# Altium schematic sheet Peripherals.SchDoc
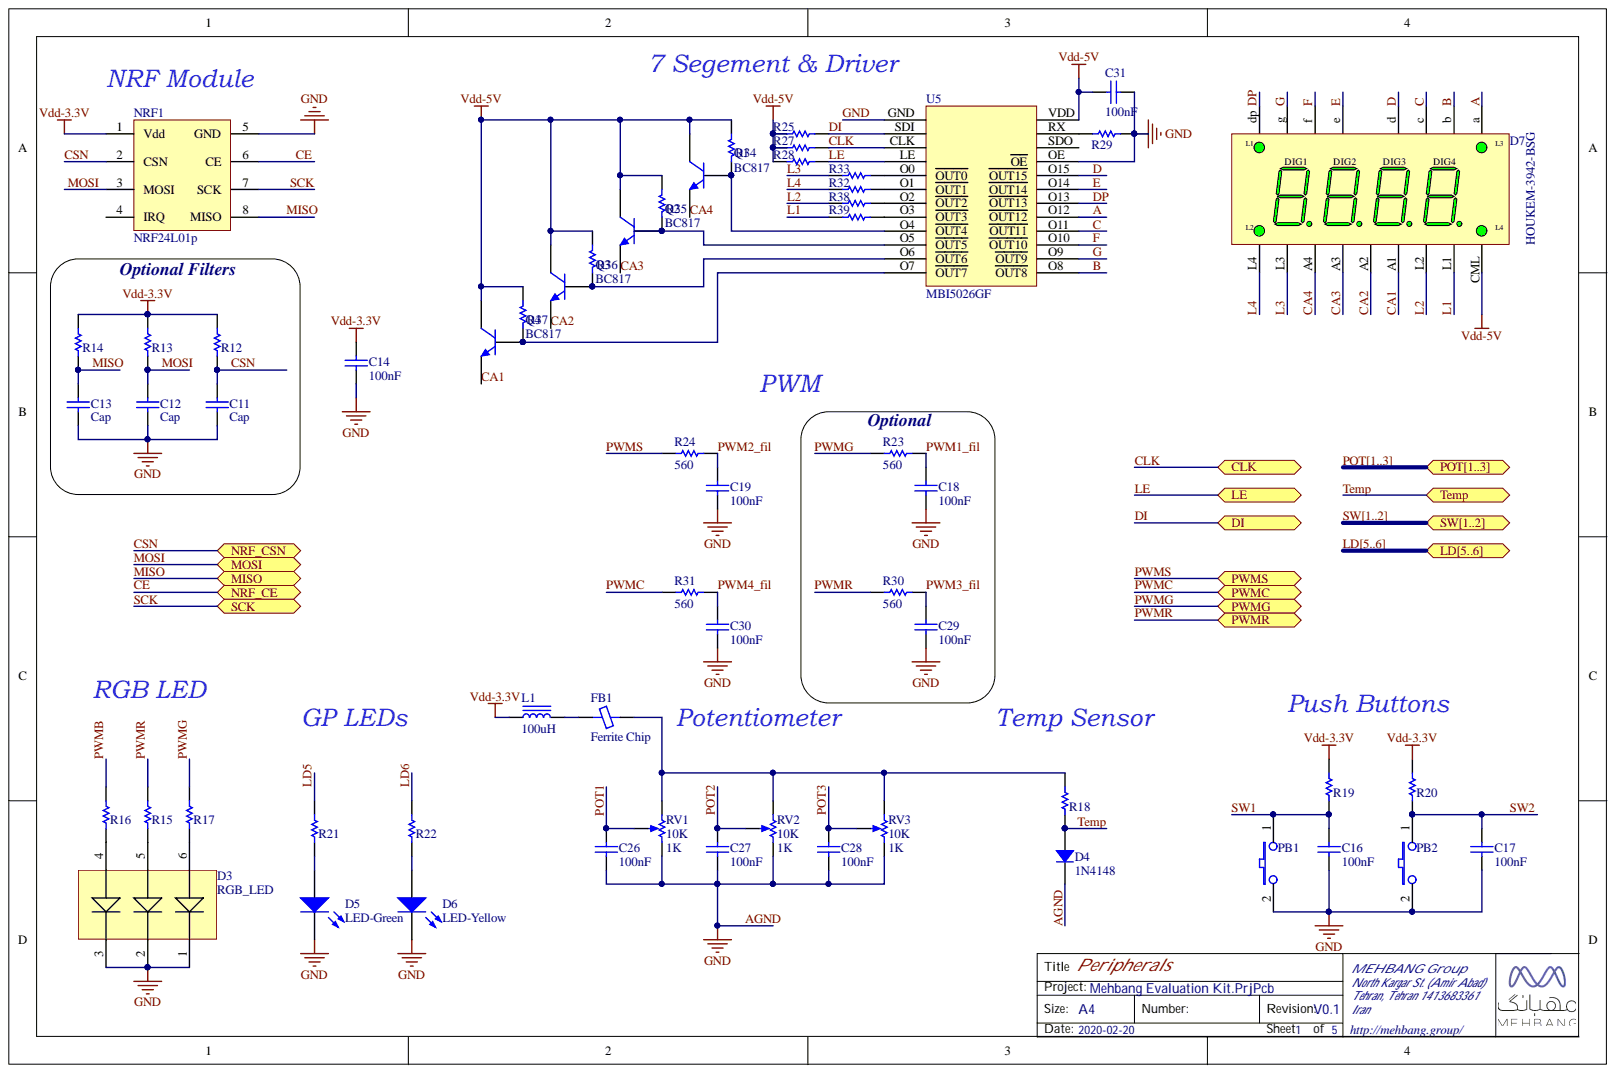
[diagram p0: Peripherals.SchDoc]

## Parts
C11  Cap  [0805-Cap]
C12  Cap  [0805-Cap]
C13  Cap  [0805-Cap]
C14  100nF  [0805-Cap]
C16  100nF  [0805-Cap]
C17  100nF  [0805-Cap]
C18  100nF  [0805-Cap]
C19  100nF  [0805-Cap]
C26  100nF  [0805-Cap]
C27  100nF  [0805-Cap]
C28  100nF  [0805-Cap]
C29  100nF  [0805-Cap]
C30  100nF  [0805-Cap]
C31  100nF  [0805-Cap]
D3  RGB_LED  [RGB 5050 LED]
D4  1N4148  [SOD-123]
D5  LED-Green  [0805-LED]
D6  LED-Yellow  [0805-LED]
D7  HOUKEM-3942-BSG  [7 SEGMENT-4DIGIT]
FB1  Ferrite Chip  [Ferrit Bid]
L1  100uH  [0805-Res]
NRF1  NRF24L01p  [NRF24L01P]
PB1  2 pin tactile switch  [PB-6X6mm-dip-2pin-Black]  (value not drawn)
PB2  2 pin tactile switch  [PB-6X6mm-dip-2pin-Black]  (value not drawn)
Q1  BC817  [SOT-23]
Q2  BC817  [SOT-23]
Q3  BC817  [SOT-23]
Q4  BC817  [SOT-23]
R12  Res  [0805-Res]  (value not drawn)
R13  Res  [0805-Res]  (value not drawn)
R14  Res  [0805-Res]  (value not drawn)
R15  220  [0805-Res]  (value not drawn)
R16  150  [0805-Res]  (value not drawn)
R17  150  [0805-Res]  (value not drawn)
R18  1.2K  [0805-Res]  (value not drawn)
R19  1K  [0805-Res]  (value not drawn)
R20  1K  [0805-Res]  (value not drawn)
R21  220  [0805-Res]  (value not drawn)
R22  330  [0805-Res]  (value not drawn)
R23  560  [0805-Res]
R24  560  [0805-Res]
R25  2.7K  [0805-Res]  (value not drawn)
R27  2.7K  [0805-Res]  (value not drawn)
R28  2.7K  [0805-Res]  (value not drawn)
R29  2.7K  [0805-Res]  (value not drawn)
R30  560  [0805-Res]
R31  560  [0805-Res]
R32  330  [0805-Res]  (value not drawn)
R33  330  [0805-Res]  (value not drawn)
R34  1K  [0805-Res]  (value not drawn)
R35  1K  [0805-Res]  (value not drawn)
R36  1K  [0805-Res]  (value not drawn)
R37  1K  [0805-Res]  (value not drawn)
R38  330  [0805-Res]  (value not drawn)
R39  330  [0805-Res]  (value not drawn)
RV1  10K  value 1K  [POT]
RV2  10K  value 1K  [POT]
RV3  10K  value 1K  [POT]
U5  MBI5026GF  [MBI5026]

## Ports
CLK, DI, LD5, LD6, LD[5..6], LE, MISO, MOSI, NRF_CE, NRF_CSN, POT1, POT2, POT3, POT[1..3], PWMC, PWMG, PWMR, PWMS, SCK, SW1, SW2, SW[1..2], Temp

## Nets
Vdd-3.3V: C14.2 L1.2 NRF1.1(Vdd) R12.2 R13.2 R14.2 R19.2 R20.2
Vdd-5V: C31.2 D7.CML Q1.3 Q2.3 Q3.3 Q4.3 R25.2 R27.2 R28.2 R34.2 R35.2 R36.2 R37.2 U5.VDD
A: D7.a U5.O12(OUT12)
AGND: C11.1 C12.1 C13.1 C14.1 C16.1 C17.1 C18.1 C19.1 C26.1 C27.1 C28.1 C29.1 C30.1 C31.1 D3.1 D3.2 D3.3 D4.2 D5.2 D6.2 NRF1.5(GND) PB1.2 PB2.2 R29.1 RV1.3 RV2.3 RV3.3 U5.GND U5.OE
B: D7.b U5.O8(OUT8)
C: D7.c U5.O11(OUT11)
CA1: D7.A1 Q4.2
CA2: D7.A2 Q3.2
CA3: D7.A3 Q2.2
CA4: D7.A4 Q1.2
CE: NRF1.6(CE) ; port NRF_CE
CLK: R27.1 U5.CLK ; port CLK
CSN: C11.2 NRF1.2(CSN) R12.1 ; port NRF_CSN
D: D7.d U5.O15(OUT15)
DI: R25.1 U5.SDI ; port DI
DP: D7.dp U5.O13(OUT13)
E: D7.e U5.O14(OUT14)
F: D7.f U5.O10(OUT10)
G: D7.g U5.O9(OUT9)
L1: D7.L1 R39.2
L2: D7.L2 R38.2
L3: D7.L3 R33.2
L4: D7.L4 R32.2
LD5: R21.2 ; port LD[5..6]  [also labelled LD]
LD6: R22.2 ; port LD[5..6]  [also labelled LD]
LE: R28.1 U5.LE ; port LE
MISO: C13.2 NRF1.8(MISO) R14.1 ; port MISO
MOSI: C12.2 NRF1.3(MOSI) R13.1 ; port MOSI
POT1: C26.2 RV1.2 ; port POT[1..3]  [also labelled POT]
POT2: C27.2 RV2.2 ; port POT[1..3]  [also labelled POT]
POT3: C28.2 RV3.2 ; port POT[1..3]  [also labelled POT]
PWM1_fil: C18.2 R23.1
PWM2_fil: C19.2 R24.1
PWM3_fil: C29.2 R30.1
PWM4_fil: C30.2 R31.1
PWMB: R16.2
PWMC: R31.2 ; port PWMC
PWMG: R17.2 R23.2 ; port PWMG
PWMR: R15.2 R30.2 ; port PWMR
PWMS: R24.2 ; port PWMS
SCK: NRF1.7(SCK) ; port SCK
SW1: C16.2 PB1.1 R19.1 ; port SW[1..2]  [also labelled SW]
SW2: C17.2 PB2.1 R20.1 ; port SW[1..2]  [also labelled SW]
Temp: D4.1 R18.1 ; port Temp
NetD3_4: D3.4 R16.1
NetD3_5: D3.5 R15.1
NetD3_6: D3.6 R17.1
NetD5_1: D5.1 R21.1
NetD6_1: D6.1 R22.1
NetFB1_1: FB1.1 L1.1
NetFB1_2: FB1.2 R18.2 RV1.1 RV2.1 RV3.1
NetQ1_1: Q1.1 R34.1 U5.O4(OUT4)
NetQ2_1: Q2.1 R35.1 U5.O5(OUT5)
NetQ3_1: Q3.1 R36.1 U5.O6(OUT6)
NetQ4_1: Q4.1 R37.1 U5.O7(OUT7)
NetR29_2: R29.2 U5.RX
NetR32_1: R32.1 U5.O1(OUT1)
NetR33_1: R33.1 U5.O0(OUT0)
NetR38_1: R38.1 U5.O2(OUT2)
NetR39_1: R39.1 U5.O3(OUT3)

Not connected: NRF1 4(IRQ); U5 SDO

## Library data of the parts
C11-C14 C16-C19 C26-C31 = Cap: Capacitor; Component Kind: Standard; LatestRevisionDate: 17-Jul-2002; LatestRevisionNote: Re-released for DXP Platform.; Library Name: DaEvKit-LIB.IntLib; PackageDescription: Radial Cap, Thru-Hole; 2 Leads; 0.3 in Pin Spacing; PackageReference: RAD-0.3; Published: 8-Jun-2000; Publisher: Altium Limited
D3 = LED 5050 RGB: Component Kind: Standard; Library Name: DaEvKit-LIB.IntLib
D4 = Diode: Rectifier Diode; Component Kind: Standard; Library Name: DaEvKit-LIB.IntLib
D5 D6 = LED: Typical RED, GREEN, YELLOW, AMBER GaAs LED; Component Kind: Standard; LatestRevisionDate: 17-Jul-2002; LatestRevisionNote: Re-released for DXP Platform.; Library Name: DaEvKit-LIB.IntLib; PackageDescription: SM LED; 2 Flat Leads; PackageReference: 3.2X1.6X1.1; PackageVersion: Feb-2002; Published: 8-Jun-2000; Publisher: Altium Limited
D7 = 7-Segment: 7-Segment Display: 4-Digit, 4 extra leds; Component Kind: Standard; Library Name: DaEvKit-LIB.IntLib
FB1 = Ferrite Chip: SMT Ferrite Chip; Component Kind: Standard; Library Name: DaEvKit-LIB.IntLib
L1 = Inductor: SMT Inductor; Component Kind: Standard; Library Name: DaEvKit-LIB.IntLib
NRF1 = NRF24L01p: female header for NRF24L01p; Component Kind: Standard; Library Name: DaEvKit-LIB.IntLib
PB1 PB2 = PUSHBUTTON 2 pin: Component Kind: Standard; Description: 2 pin tactile switch; Library Name: DaEvKit-LIB.IntLib
Q1-Q4 = QNPN: NPN Bipolar Transistor; Component Kind: Standard; LatestRevisionDate: 29-May-2009; LatestRevisionNote: IPC-7351 Footprint Added.; Library Name: DaEvKit-LIB.IntLib; PackageDescription: 3-Pin SOT-23 Package 0.95 mm Pitch, 2.4 mm Lead Span; PackageReference: SOT-23B; PackageVersion: Aug-1999; Published: 8-Jun-2000; Publisher: Altium Limited
R12-R25 R27-R39 = Res: Resistor; Code_IPC: 2012 [0805]; Component Kind: Standard; ComponentLink1Description: Manufacturer Link; ComponentLink1URL: http://www.panasonic.com/industrial/components/resistive_products.htm; ComponentLink2Description: Datasheet; ComponentLink2URL: http://www.panasonic.com/industrial/components/pdf/AOF0000CE1.pdf; LatestRevisionNote: Silkscreen size reduced.; Library Name: DaEvKit-LIB.IntLib; Publisher: Altium Limited
RV1-RV3 = RPot: Potentiometer; Set Position: 0.5; Sim Note: Enter the Resistance value and the set point into parameter fields.; Component Kind: Standard; LatestRevisionDate: 17-Jul-2002; LatestRevisionNote: Re-released for DXP Platform.; Library Name: DaEvKit-LIB.IntLib; PackageDescription: Variable Resistor, Thru-Hole; 3 Leads; PackageReference: VR5; Published: 8-Jun-2000; Publisher: Altium Limited
U5 = MBI5026: Component Kind: Standard; Library Name: DaEvKit-LIB.IntLib
